# Altium schematic sheet Sheet6.SchDoc
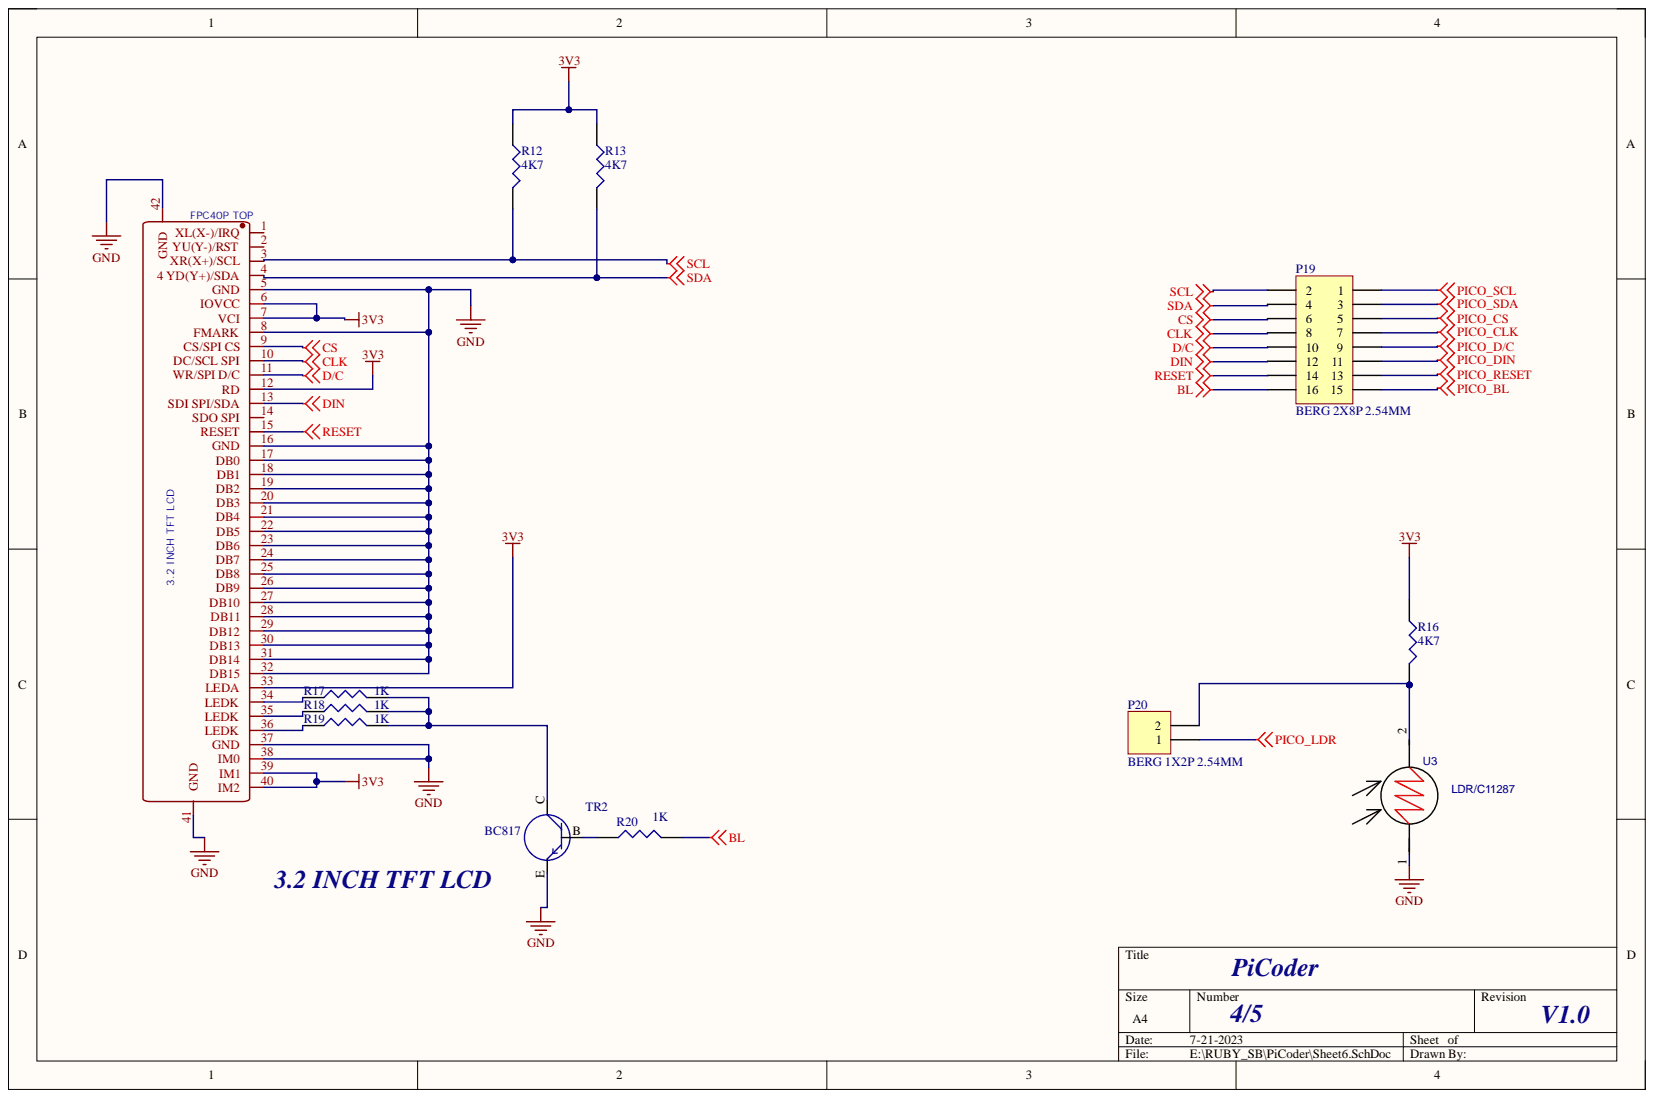
[diagram p0: Sheet6.SchDoc]

## Parts
FPC40P_TOP  3.2 INCH TFT LCD  [3.2 TFT LC]
P19  BERG 2X8P 2.54MM  [2X8P 2.54MM]
P20  BERG 1X2P 2.54MM  [2P_2.54MM]
R12  4K7  [R_0603]
R13  4K7  [R_0603]
R16  4K7  [R_0603]
R17  1K  [R_0603]
R18  1K  [R_0603]
R19  1K  [R_0603]
R20  1K  [R_0603]
TR2  BC817  [SOT23]
U3  LDR/C11287  [LDR_2MM]

## Nets
3V3: FPC40P_TOP.6(IOVCC) FPC40P_TOP.7(VCI) FPC40P_TOP.12(RD) FPC40P_TOP.33(LEDA) FPC40P_TOP.39(IM1) FPC40P_TOP.40(IM2) R12.1 R13.1 R16.1
BL: P19.16 R20.1
CLK: FPC40P_TOP.10(DC/SCL_SPI) P19.8
CS: FPC40P_TOP.9(CS/SPI_CS) P19.6
D/C: FPC40P_TOP.11(WR/SPI_D/C) P19.10
DIN: FPC40P_TOP.13(SDI_SPI/SDA) P19.12
GND: FPC40P_TOP.5(GND) FPC40P_TOP.8(FMARK) FPC40P_TOP.16(GND) FPC40P_TOP.17(DB0) FPC40P_TOP.18(DB1) FPC40P_TOP.19(DB2) FPC40P_TOP.20(DB3) FPC40P_TOP.21(DB4) FPC40P_TOP.22(DB5) FPC40P_TOP.23(DB6) FPC40P_TOP.24(DB7) FPC40P_TOP.25(DB8) FPC40P_TOP.26(DB9) FPC40P_TOP.27(DB10) FPC40P_TOP.28(DB11) FPC40P_TOP.29(DB12) FPC40P_TOP.30(DB13) FPC40P_TOP.31(DB14) FPC40P_TOP.32(DB15) FPC40P_TOP.37(GND) FPC40P_TOP.38(IM0) FPC40P_TOP.41(GND) FPC40P_TOP.42(GND) TR2.E U3.1
PICO_BL: P19.15
PICO_CLK: P19.7
PICO_CS: P19.5
PICO_D/C: P19.9
PICO_DIN: P19.11
PICO_LDR: P20.1
PICO_RESET: P19.13
PICO_SCL: P19.1
PICO_SDA: P19.3
RESET: FPC40P_TOP.15(RESET) P19.14
SCL: FPC40P_TOP.3(XR(X+)/SCL) P19.2 R12.2
SDA: FPC40P_TOP.4(4_YD(Y+)/SDA) P19.4 R13.2
NetFPC40P TOP_34: FPC40P_TOP.34(LEDK) R17.1
NetFPC40P TOP_35: FPC40P_TOP.35(LEDK) R18.1
NetFPC40P TOP_36: FPC40P_TOP.36(LEDK) R19.1
NetP20_2: P20.2 R16.2 U3.2
NetR17_2: R17.2 R18.2 R19.2 TR2.C
NetR20_2: R20.2 TR2.B

Not connected: FPC40P_TOP 1(XL(X-)/IRQ) 2(YU(Y-)/RST) 14(SDO_SPI)

## Library data of the parts
FPC40P_TOP = FPC-40P: Component Kind: Standard; Library Name: MIX.SchLib
P19 = Header 8X2: Header, 8-Pin, Dual row; Component Kind: Standard; LatestRevisionDate: 17-Jul-2002; LatestRevisionNote: Re-released for DXP Platform.; Library Name: Miscellaneous Connectors.IntLib; Signal Integrity: Connector; Publisher: Altium Limited
P20 = Header 2: Header, 2-Pin; Component Kind: Standard; LatestRevisionDate: 17-Jul-2002; LatestRevisionNote: Re-released for DXP Platform.; Library Name: Miscellaneous Connectors.IntLib; Signal Integrity: Connector; Publisher: Altium Limited
R12 R13 R16-R20 = RES: Component Kind: Standard; Library Name: dxp_pasl_lib.IntLib
TR2 = TRANSISTER_NPN: Component Kind: Standard; Library Name: dxp_pasl_lib.IntLib
U3 = LDR: Contributor: nicolascorrea2013; nameAlias: Model; sourceId: taKkGgPNL; Component Kind: Standard; spicePre: X; spiceSymbolName: LDR; timeStamp: 1464930495; Library Name: MIX.SchLib
